# Altium schematic sheet ZED_F9P.SchDoc
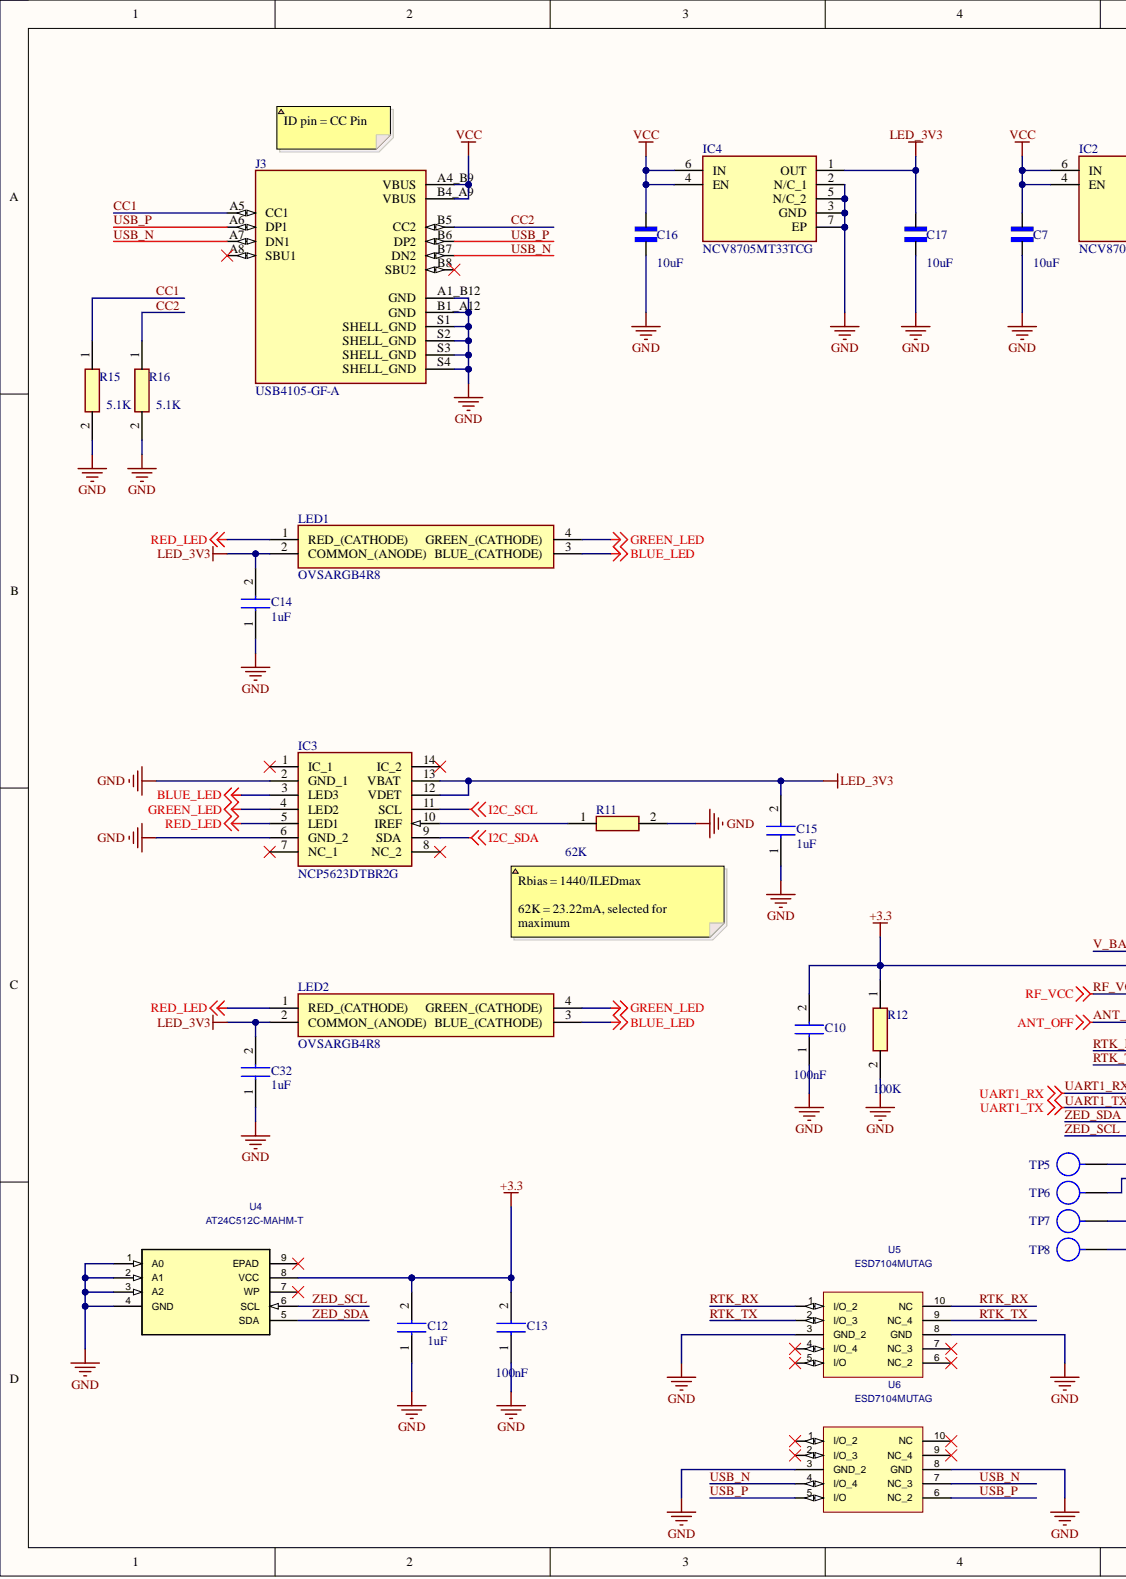
[diagram p0: ZED_F9P.SchDoc, left]
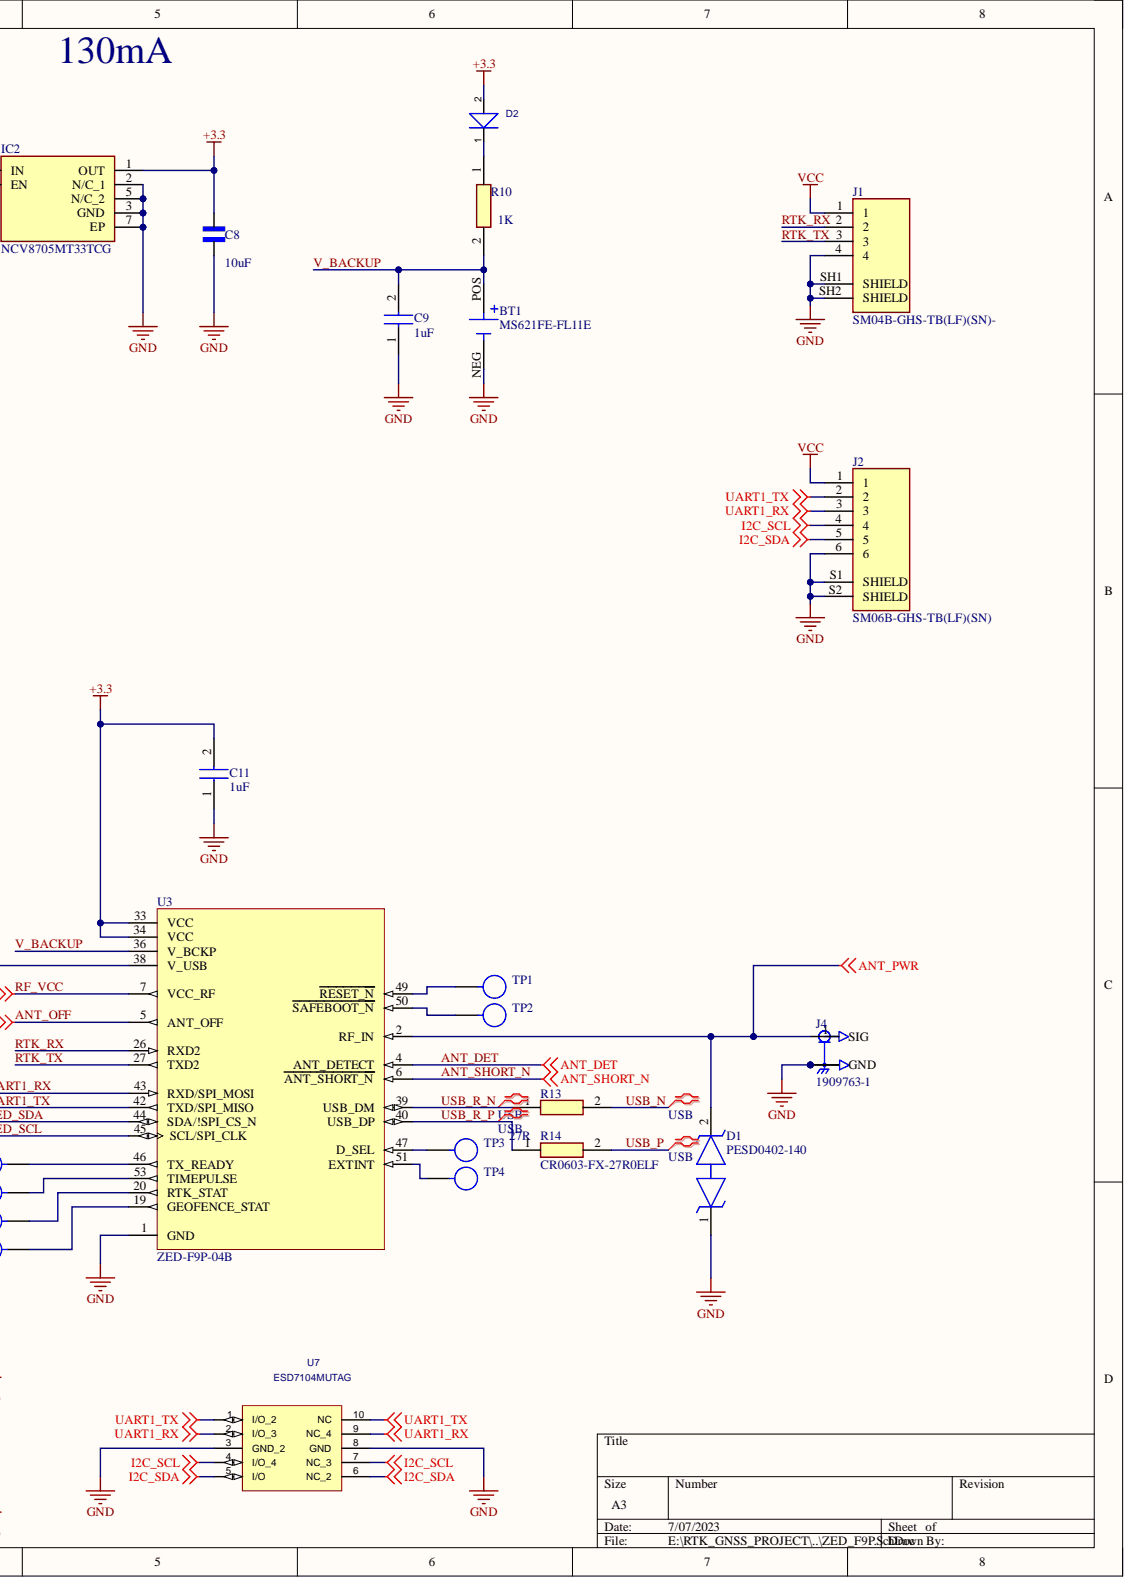
[diagram p1: ZED_F9P.SchDoc, right]

## Parts
BT1  MS621FE-FL11E  [BAT_MS621FE-FL11E]
C7  value 10uF  [CAPC2012X135N-0805]  (hidden comment: CL21X106KAYQNWE)
C8  value 10uF  [CAPC2012X135N-0805]  (hidden comment: CL21X106KAYQNWE)
C9  value 1uF  [CAPC3216X110N]  (hidden comment: C1206C105K5RACAUTO)
C10  Parameter 1: 100nF  [CAPC1005X60N]  (hidden comment: C0402C104K8PACTU)
C11  value 1uF  [CAPC3216X110N]  (hidden comment: C1206C105K5RACAUTO)
C12  value 1uF  [CAPC3216X110N]  (hidden comment: C1206C105K5RACAUTO)
C13  Parameter 1: 100nF  [CAPC1005X60N]  (hidden comment: C0402C104K8PAC7867)
C14  value 1uF  [CAPC3216X110N]  (hidden comment: C1206C105K5RACAUTO)
C15  value 1uF  [CAPC3216X110N]  (hidden comment: C1206C105K5RACAUTO)
C16  value 10uF  [CAPC2012X135N-0805]  (hidden comment: CL21X106KAYQNWE)
C17  value 10uF  [CAPC2012X135N-0805]  (hidden comment: CL21X106KAYQNWE)
C32  value 1uF  [CAPC3216X110N]  (hidden comment: C1206C105K5RACAUTO)
D1  PESD0402-140  [DIONC1005X43N]
D2  RB751VM-40FHTE-1  [DIO_RB500V_ROM]  (value not drawn)
IC2  NCV8705MT33TCG  [SON65P200X200X80-7N]
IC3  NCP5623DTBR2G  [SOP65P640X120-14N]
IC4  NCV8705MT33TCG  [SON65P200X200X80-7N]
J1  SM04B-GHS-TB(LF)(SN)-  [JST_SM04B-GHS-TB(LF)(SN)-]
J2  SM06B-GHS-TB(LF)(SN)  [JST_SM06B-GHS-TB(LF)(SN)]
J3  USB4105-GF-A  [GCT_USB4105-GF-A]
J4  1909763-1  [TE_1909763-1]
LED1  OVSARGB4R8  [OVSARGB4R8]
LED2  OVSARGB4R8  [OVSARGB4R8]
R10  Parameter 1: 1K  [RESC1608X55N]  (hidden comment: RMCF0201FT1K00)
R11  Parameter 1: 62K  [RESC1608X55N]  (hidden comment: RMCF0603FT62K0)
R12  Parameter 1: 100K  [RESC1608X55N]  (hidden comment: RMCF0603FG100K)
R13  CR0603-FX-27R0ELF  [RESC1608X55N]
R14  CR0603-FX-27R0ELF  [RESC1608X55N]
R15  RMCF0603FT5K10  [RESC1608X55N]  (value not drawn)
R16  Parameter 1: 5.1K  [RESC1608X55N]  (hidden comment: RMCF0603FT5K10)
TP1  Test Point  [TEST PAD]  (value not drawn)
TP2  Test Point  [TEST PAD]  (value not drawn)
TP3  Test Point  [TEST PAD]  (value not drawn)
TP4  Test Point  [TEST PAD]  (value not drawn)
TP5  Test Point  [TEST PAD]  (value not drawn)
TP6  Test Point  [TEST PAD]  (value not drawn)
TP7  Test Point  [TEST PAD]  (value not drawn)
TP8  Test Point  [TEST PAD]  (value not drawn)
U3  ZED-F9P-04B  [XCVR_ZED-F9P-00B-02]
U4  AT24C512C-MAHM-T  [8MA2_ATM]
U5  ESD7104MUTAG  [UDFN10_ONS]
U6  ESD7104MUTAG  [UDFN10_ONS]
U7  ESD7104MUTAG  [UDFN10_ONS]

## Nets
+3.3: C8.1 C10.2 C11.2 C12.2 C13.2 D2.2 IC2.1(OUT) R12.1 U3.33(VCC) U3.34(VCC) U3.38(V_USB) U4.8(VCC)
ANT_PWR: D1.2 J4.1 U3.2(RF_IN)
BLUE_LED: IC3.3(LED3) LED1.3(BLUE_(CATHODE)) LED2.3(BLUE_(CATHODE))
GND: BT1.NEG C7.2 C8.2 C9.1 C10.1 C11.1 C12.1 C13.1 C14.1 C15.1 C16.2 C17.2 C32.1 D1.1 IC2.2(N/C_1) IC2.3(GND) IC2.5(N/C_2) IC2.7(EP) IC3.2(GND_1) IC3.6(GND_2) IC4.2(N/C_1) IC4.3(GND) IC4.5(N/C_2) IC4.7(EP) J1.4 J1.SH1(SHIELD) J1.SH2(SHIELD) J2.6 J2.S1(SHIELD) J2.S2(SHIELD) J3.A1_B12(GND) J3.B1_A12(GND) J3.S1(SHELL_GND) J3.S2(SHELL_GND) J3.S3(SHELL_GND) J3.S4(SHELL_GND) J4.2 J4.3 R11.2 R12.2 R15.2 R16.2 U3.1(GND) U4.1(A0) U4.2(A1) U4.3(A2) U4.4(GND) U5.3(GND_2) U5.8(GND) U6.3(GND_2) U6.8(GND) U7.3(GND_2) U7.8(GND)
GREEN_LED: IC3.4(LED2) LED1.4(GREEN_(CATHODE)) LED2.4(GREEN_(CATHODE))
I2C_SCL: IC3.11(SCL) J2.4 U7.4(I/O_4) U7.7(NC_3)
I2C_SDA: IC3.9(SDA) J2.5 U7.5(I/O) U7.6(NC_2)
LED_3V3: C14.2 C15.2 C17.1 C32.2 IC3.12(VDET) IC3.13(VBAT) IC4.1(OUT) LED1.2(COMMON_(ANODE)) LED2.2(COMMON_(ANODE))
RED_LED: IC3.5(LED1) LED1.1(RED_(CATHODE)) LED2.1(RED_(CATHODE))
VCC: C7.1 C16.1 IC2.4(EN) IC2.6(IN) IC4.4(EN) IC4.6(IN) J1.1 J2.1 J3.A4_B9(VBUS) J3.B4_A9(VBUS)
ANT_DET: U3.4(ANT_DETECT)
ANT_OFF: U3.5(ANT_OFF)
ANT_SHORT_N: U3.6(ANT_SHORT_N)
CC1: J3.A5(CC1) R15.1
CC2: J3.B5(CC2) R16.1
RF_VCC: U3.7(VCC_RF)
RTK_RX: J1.2 U3.26(RXD2) U5.1(I/O_2) U5.10(NC)
RTK_TX: J1.3 U3.27(TXD2) U5.2(I/O_3) U5.9(NC_4)
UART1_RX: J2.3 U3.43(RXD/SPI_MOSI) U7.2(I/O_3) U7.9(NC_4)
UART1_TX: J2.2 U3.42(TXD/SPI_MISO) U7.1(I/O_2) U7.10(NC)
USB_N: J3.A7(DN1) J3.B7(DN2) R13.2 U6.4(I/O_4) U6.7(NC_3)
USB_P: J3.A6(DP1) J3.B6(DP2) R14.2 U6.5(I/O) U6.6(NC_2)
USB_R_N: R13.1 U3.39(USB_DM)
USB_R_P: R14.1 U3.40(USB_DP)
V_BACKUP: BT1.POS C9.2 R10.2 U3.36(V_BCKP)
ZED_SCL: U3.45(SCL/SPI_CLK) U4.6(SCL)
ZED_SDA: U3.44(SDA/!SPI_CS_N) U4.5(SDA)
NetD2_1: D2.1 R10.1
NetIC3_10: IC3.10(IREF) R11.1
NetTP1_1: TP1.1 U3.49(RESET_N)
NetTP2_1: TP2.1 U3.50(SAFEBOOT_N)
NetTP3_1: TP3.1 U3.47(D_SEL)
NetTP4_1: TP4.1 U3.51(EXTINT)
NetTP5_1: TP5.1 U3.46(TX_READY)
NetTP6_1: TP6.1 U3.53(TIMEPULSE)
NetTP7_1: TP7.1 U3.20(RTK_STAT)
NetTP8_1: TP8.1 U3.19(GEOFENCE_STAT)

Not connected: IC3 1(IC_1) 7(NC_1) 8(NC_2) 14(IC_2); J3 A8(SBU1) B8(SBU2); U4 7(WP) 9(EPAD); U5 4(I/O_4) 5(I/O) 6(NC_2) 7(NC_3); U6 1(I/O_2) 2(I/O_3) 9(NC_4) 10(NC)

## Library data of the parts
BT1 = MS621FE-FL11E: Coin, 6.8mm 3V Lithium Battery Rechargeable (Secondary) 5.5mAh; MF: Seiko Instruments; Availability: In Stock; Check_prices: https://www.snapeda.com/parts/MS621FE-FL11E/Seiko%20Instruments/view-part/6886595/?ref=eda; Component Kind: Standard; MP: MS621FE-FL11E; Package: QFP-128 Seiko; Price: None; Purchase-URL: https://pricing.snapeda.com/search/part/MS621FE-FL11E/?ref=eda; SnapEDA_Link: https://www.snapeda.com/parts/MS621FE-FL11E/Seiko%20Instruments/view-part/6886595/?ref=snap; Library Name: RTK_Schlib.SchLib
C7 C8 C16 C17 = GRM21BR61C226ME44K: 10 µF ±10% 25V Ceramic Capacitor X6S 0805 (2012 Metric); MF: Murata Electronics; Availability: Warning; Component Kind: Standard; Library Name: Schlib.SchLib; MP: GRM21BR61C226ME44K; Package: 0805 Murata; Price: None; Purchase-URL: https://pricing.snapeda.com/search/part/GRM21BR61C226ME44K/?ref=eda; SnapEDA_Link: https://www.snapeda.com/parts/GRM21BR61C226ME44K/Murata%20Electronics%20North%20America/view-part/1073502/?ref=snap
C9 C11 C12 C14 C15 C32 = C1206C105K5RACAUTO: 1µF ±10% 50V Ceramic Capacitor X7R 1206 (3216 Metric); Manufacturer_Name: Kemet; Arrow Part Number: C1206C105K5RACAUTO; Arrow Price/Stock: https://www.arrow.com/en/products/c1206c105k5racauto/kemet-corporation; Component Kind: Standard; Datasheet Link: https://api.kemet.com/component-edge/download/datasheet/C1206C105K5RACAUTO.pdf; Height: 1.1mm; Manufacturer_Part_Number: C1206C105K5RACAUTO; Mouser Part Number: 80-C1206C105K5RAUTO; Mouser Price/Stock: https://www.mouser.co.uk/ProductDetail/KEMET/C1206C105K5RACAUTO/?qs=LWKvDm6ECZBUq0ahiO2pbw%3D%3D; Library Name: RTK_Schlib.SchLib
C10 C13 = C0402C104K8PACTU: 0.1µF ±10% 10V Ceramic Capacitor X5R 0402 (1005 Metric); Manufacturer_Name: Kemet; Arrow Part Number: C0402C104K8PACTU; Arrow Price/Stock: https://www.arrow.com/en/products/c0402c104k8pactu/kemet-corporation; Component Kind: Standard; Manufacturer_Part_Number: C0402C104K8PACTU; Mouser Part Number: 80-C0402C104K8P; Mouser Price/Stock: https://www.mouser.co.uk/ProductDetail/KEMET/C0402C104K8PACTU?qs=z2sx7fVyb8jnMSbeCX%252BaWg%3D%3D; Library Name: RTK_Schlib.SchLib
D1 = PESD0402-140: 40V Clamp Ipp Tvs Diode Surface Mount 0402; Manufacturer_Name: LITTELFUSE; Arrow Part Number: PESD0402-140; Arrow Price/Stock: https://www.arrow.com/en/products/pesd0402-140/littelfuse; Component Kind: Standard; Datasheet Link: https://www.littelfuse.com/~/media/electronics/product_specifications/polymer_esd_suppressors/littelfuse_polymer_esd_suppressor_pesd0402_140_product_specification.pdf.pdf; Height: 0.43mm; Manufacturer_Part_Number: PESD0402-140; Mouser Part Number: 650-PESD0402-140; Mouser Price/Stock: https://www.mouser.co.uk/ProductDetail/Littelfuse/PESD0402-140/?qs=y1EbU1W0OrtTHVfbQV6MTg%3D%3D; Library Name: RTK_Schlib.SchLib
D2 = RB751VM-40FHTE-1: Diode Schottky 30 V 30mA Surface Mount UMD2; Manufacturer_Part_Number: RB751VM-40FHTE-17; Built_By: EMA_Matt; C: Copyright (C) 2018 Accelerated Designs. All rights reserved; SourceLibrary: Rohm_2019-09-23; Vendor: ROHM Semiconductor; Component Kind: Standard; Value: RB751VM-40FHTE-1; Copyright: Copyright (C) 2018 Accelerated Designs. All rights reserved; Library Name: Schlib_FMU.SchLib
IC2 IC4 = NCV8705MT33TCG: Linear Voltage Regulator IC Positive Fixed 1 Output 500mA 6-WDFN (2x2); Manufacturer_Name: ON Semiconductor; Component Kind: Standard; Datasheet Link: https://mouser.componentsearchengine.com/Datasheets/2/NCV8705MT33TCG.pdf; Height: 0.8mm; Manufacturer_Part_Number: NCV8705MT33TCG; Mouser Part Number: 863-NCV8705MT33TCG; Mouser Price/Stock: https://www.mouser.com/Search/Refine.aspx?Keyword=863-NCV8705MT33TCG; Library Name: RTK_Schlib.SchLib
IC3 = NCP5623DTBR2G: LED Driver IC 3 Output Linear I²C, PWM Dimming 30mA 14-TSSOP; Manufacturer_Name: ON Semiconductor; Arrow Part Number: NCP5623DTBR2G; Arrow Price/Stock: https://www.arrow.com/en/products/ncp5623dtbr2g/on-semiconductor; Component Kind: Standard; Datasheet Link: http://www.onsemi.com/pub/Collateral/NCP5623-D.PDF; Height: 1.2mm; Manufacturer_Part_Number: NCP5623DTBR2G; Mouser Part Number: 863-NCP5623DTBR2G; Mouser Price/Stock: https://www.mouser.co.uk/ProductDetail/ON-Semiconductor/NCP5623DTBR2G?qs=atIEnC%2F2K4UXlMf9SxIM5g%3D%3D; Library Name: Schlib_FMU.SchLib
J1 = SM04B-GHS-TB(LF)(SN)-: Connector Header Surface Mount, Right Angle 4 position 0.049 _1.25mm_; MF: JST Sales; MP: SM04B-GHS-TB_LF__SN_-; Availability: Unavailable; Component Kind: Standard; Package: None; Price: None; SnapEDA_Link: https://www.snapeda.com/parts/SM04B-GHS-TB%28LF%29%28SN%29-/JST%20Sales%20America%20Inc./view-part/2759740/?ref=snap; Library Name: Schlib_FMU.SchLib
J2 = SM06B-GHS-TB(LF)(SN): Connector Header Surface Mount, Right Angle 6 position 0.049" (1.25mm); MF: JST Sales; MP: SM06B-GHS-TB_LF__SN_; Availability: Unavailable; Component Kind: Standard; Package: None; Price: None; SnapEDA_Link: https://www.snapeda.com/parts/SM06B-GHS-TB%28LF%29%28SN%29/JST%20Sales%20America%20Inc./view-part/817690/?ref=snap; Library Name: Schlib_FMU.SchLib
J3 = USB4105-GF-A: USB-C (USB TYPE-C) USB 2.0 Receptacle Connector 24 (16+8 Dummy) Position Surface Mount, Right Angle; Through Hole; MF: GCT; Availability: Warning; Check_prices: https://www.snapeda.com/parts/USB4105-GF-A/Global%20Connector%20Technology/view-part/4423780/?ref=eda; Component Kind: Standard; MP: USB4105-GF-A; Package: None; Price: None; Purchase-URL: https://pricing.snapeda.com/search/part/USB4105-GF-A/?ref=eda; SnapEDA_Link: https://www.snapeda.com/parts/USB4105-GF-A/Global%20Connector%20Technology/view-part/4423780/?ref=snap; Library Name: RTK_Schlib.SchLib
J4 = 1909763-1: U.FL (UMCC) Connector Jack, Male Pin 50Ohm Surface Mount Solder; MF: TE Connectivity; Number_of_Positions: 1; Availability: Unavailable; Component Kind: Standard; MP: 1909763-1; Package: None; Price: None; SnapEDA_Link: https://www.snapeda.com/parts/1909763-1/TE%20Connectivity%20AMP%20Connectors/view-part/473568/?ref=snap; TE_purchase_URL: https://www.te.com/usa-en/product-1909763-1.html?te_bu=Cor&te_type=disp&te_campaign=seda_glo_cor-seda-global-disp-prtnr-fy19-seda-model-bom-cta_sma-317_1&elqCampaignId=32493; Library Name: RTK_Schlib.SchLib
LED1 LED2 = OVSARGB4R8: Red, Green, Blue (RGB) 622nm Red, 530nm Green, 468nm Blue LED Indication - Discrete 2V Red, 3.2V Green, 3.2V Blue 4-PLCC; Manufacturer_Name: OPTEK TECHNOLOGY; Arrow Part Number: OVSARGB4R8; Arrow Price/Stock: https://www.arrow.com/en/products/ovsargb4r8/optek-technology; Component Kind: Standard; Datasheet Link: https://componentsearchengine.com/Datasheets/1/OVSARGB4R8.pdf; Height: 2.1mm; Manufacturer_Part_Number: OVSARGB4R8; Mouser Part Number: 828-OVSARGB4R8; Mouser Price/Stock: https://www.mouser.co.uk/ProductDetail/Optek-TT-Electronics/OVSARGB4R8/?qs=R5enTUWy33qLiiCMTWkYfg%3D%3D; Library Name: Schlib_FMU.SchLib
R10 = RC0603FR-07330RL: 1 kOhms ±1% 0.05W, 1/20W Chip Resistor 0201 (0603 Metric) - Thick Film; Manufacturer_Name: YAGEO (PHYCOMP); Arrow Part Number: RC0603FR-07330RL; Arrow Price/Stock: https://www.arrow.com/en/products/rc0603fr-07330rl/yageo; Component Kind: Standard; Datasheet Link: http://www.yageo.com/documents/recent/PYu-RC0603_51_RoHS_L_v5.pdf; Height: 0.55mm; Manufacturer_Part_Number: RC0603FR-07330RL; Mouser Part Number: 603-RC0603FR-07330RL; Mouser Price/Stock: https://www.mouser.co.uk/ProductDetail/Yageo/RC0603FR-07330RL?qs=diQw95jMAeN1335dAOzMfg%3D%3D; Library Name: RTK_Schlib.SchLib
R11 = RC0603FR-07330RL: 62 kOhms ±1% 0.1W, 1/10W Chip Resistor 0603 (1608 Metric) Automotive AEC-Q200 Thick Film; Manufacturer_Name: YAGEO (PHYCOMP); Arrow Part Number: RC0603FR-07330RL; Arrow Price/Stock: https://www.arrow.com/en/products/rc0603fr-07330rl/yageo; Component Kind: Standard; Datasheet Link: http://www.yageo.com/documents/recent/PYu-RC0603_51_RoHS_L_v5.pdf; Height: 0.55mm; Manufacturer_Part_Number: RC0603FR-07330RL; Mouser Part Number: 603-RC0603FR-07330RL; Mouser Price/Stock: https://www.mouser.co.uk/ProductDetail/Yageo/RC0603FR-07330RL?qs=diQw95jMAeN1335dAOzMfg%3D%3D; Library Name: RTK_Schlib.SchLib
R12 = RC0603FR-07330RL: 100 kOhms ±1% 0.1W, 1/10W Chip Resistor 0603 (1608 Metric) Automotive AEC-Q200 Thick Film; Manufacturer_Name: YAGEO (PHYCOMP); Arrow Part Number: RC0603FR-07330RL; Arrow Price/Stock: https://www.arrow.com/en/products/rc0603fr-07330rl/yageo; Component Kind: Standard; Datasheet Link: http://www.yageo.com/documents/recent/PYu-RC0603_51_RoHS_L_v5.pdf; Height: 0.55mm; Manufacturer_Part_Number: RC0603FR-07330RL; Mouser Part Number: 603-RC0603FR-07330RL; Mouser Price/Stock: https://www.mouser.co.uk/ProductDetail/Yageo/RC0603FR-07330RL?qs=diQw95jMAeN1335dAOzMfg%3D%3D; Library Name: RTK_Schlib.SchLib
R13 = RC0603FR-07330RL: 27 Ohms ±1% 0.1W, 1/10W Chip Resistor 0603 (1608 Metric) Moisture Resistant Thick Film; Manufacturer_Name: YAGEO (PHYCOMP); Parameter 1: 27R; Arrow Part Number: RC0603FR-07330RL; Arrow Price/Stock: https://www.arrow.com/en/products/rc0603fr-07330rl/yageo; Component Kind: Standard; Datasheet Link: http://www.yageo.com/documents/recent/PYu-RC0603_51_RoHS_L_v5.pdf; Height: 0.55mm; Manufacturer_Part_Number: RC0603FR-07330RL; Mouser Part Number: 603-RC0603FR-07330RL; Mouser Price/Stock: https://www.mouser.co.uk/ProductDetail/Yageo/RC0603FR-07330RL?qs=diQw95jMAeN1335dAOzMfg%3D%3D; Library Name: RTK_Schlib.SchLib
R14 = RC0603FR-07330RL: 27 Ohms ±1% 0.1W, 1/10W Chip Resistor 0603 (1608 Metric) Moisture Resistant Thick Film; Manufacturer_Name: YAGEO (PHYCOMP); Arrow Part Number: RC0603FR-07330RL; Arrow Price/Stock: https://www.arrow.com/en/products/rc0603fr-07330rl/yageo; Component Kind: Standard; Datasheet Link: http://www.yageo.com/documents/recent/PYu-RC0603_51_RoHS_L_v5.pdf; Height: 0.55mm; Manufacturer_Part_Number: RC0603FR-07330RL; Mouser Part Number: 603-RC0603FR-07330RL; Mouser Price/Stock: https://www.mouser.co.uk/ProductDetail/Yageo/RC0603FR-07330RL?qs=diQw95jMAeN1335dAOzMfg%3D%3D; Library Name: RTK_Schlib.SchLib
R15 = RC0603FR-07330RL: 5.1 kOhms ±1% 0.1W, 1/10W Chip Resistor 0603 (1608 Metric) Automotive AEC-Q200 Thick Film; Manufacturer_Name: YAGEO (PHYCOMP); Parameter 1: 5.1K; Arrow Part Number: RC0603FR-07330RL; Arrow Price/Stock: https://www.arrow.com/en/products/rc0603fr-07330rl/yageo; Component Kind: Standard; Datasheet Link: http://www.yageo.com/documents/recent/PYu-RC0603_51_RoHS_L_v5.pdf; Height: 0.55mm; Manufacturer_Part_Number: RC0603FR-07330RL; Mouser Part Number: 603-RC0603FR-07330RL; Mouser Price/Stock: https://www.mouser.co.uk/ProductDetail/Yageo/RC0603FR-07330RL?qs=diQw95jMAeN1335dAOzMfg%3D%3D; Library Name: RTK_Schlib.SchLib
R16 = RC0603FR-07330RL: 5.1 kOhms ±1% 0.1W, 1/10W Chip Resistor 0603 (1608 Metric) Automotive AEC-Q200 Thick Film; Manufacturer_Name: YAGEO (PHYCOMP); Arrow Part Number: RC0603FR-07330RL; Arrow Price/Stock: https://www.arrow.com/en/products/rc0603fr-07330rl/yageo; Component Kind: Standard; Datasheet Link: http://www.yageo.com/documents/recent/PYu-RC0603_51_RoHS_L_v5.pdf; Height: 0.55mm; Manufacturer_Part_Number: RC0603FR-07330RL; Mouser Part Number: 603-RC0603FR-07330RL; Mouser Price/Stock: https://www.mouser.co.uk/ProductDetail/Yageo/RC0603FR-07330RL?qs=diQw95jMAeN1335dAOzMfg%3D%3D; Library Name: RTK_Schlib.SchLib
U3 = ZED-F9P-00B-02: series RF Receiver BeiDou, Galileo, GLONASS, GNSS, GPS 1.2276GHz, 1.575GHz -167dBm 921.6kbps 54-LGA (22x17); Component Kind: Standard; Library Name: RTK_Schlib.SchLib
U4 = AT24C512C-MAHM-T: EEPROM Memory IC 512Kb (64K x 8) I²C 400 kHz 900 ns 8-UDFN (2x3); Mfr_Name: Microchip; C: Copyright (C) 2015 Accelerated Designs. All rights reserved; Component Kind: Standard; Digi-Key_Part_Number_1: AT24C512C-MAHM-TCT-ND; Digi-Key_Part_Number_2: AT24C512C-MAHM-TDKR-ND; Digi-Key_Part_Number_3: AT24C512C-MAHM-TTR-ND; Manufacturer_Part_Number: AT24C512C-MAHM-T; Value: AT24C512C-MAHM-T; Copyright: Copyright (C) 2022 Ultra Librarian. All rights reserved.; Library Name: RTK_Schlib.SchLib
U5-U7 = ESD7104MUTAG: 10V Clamp 1A (8/20µs) Ipp Tvs Diode Surface Mount 10-UDFN (2.5x1); Built_By: EMA_Justin; C: Copyright (C) 2018 Accelerated Designs. All rights reserved; SourceLibrary: Add_to_database_2020-10-16; Vendor: ON Semiconductor; Component Kind: Standard; Datasheet: https://www.mouser.com/datasheet/2/308/ESD7104-D-1101067.pdf; Manufacturer_Part_Number: ESD7104MUTAG; Value: ESD7104MUTAG; Copyright: Copyright (C) 2018 Accelerated Designs. All rights reserved; Library Name: Schlib_FMU.SchLib
TP1-TP8 = Test Point: Component Kind: Standard; Library Name: Schlib_FMU.SchLib
